# Altium schematic sheet 04-PCIe_JTAG.SchDoc(04-PCIe_JTAG)
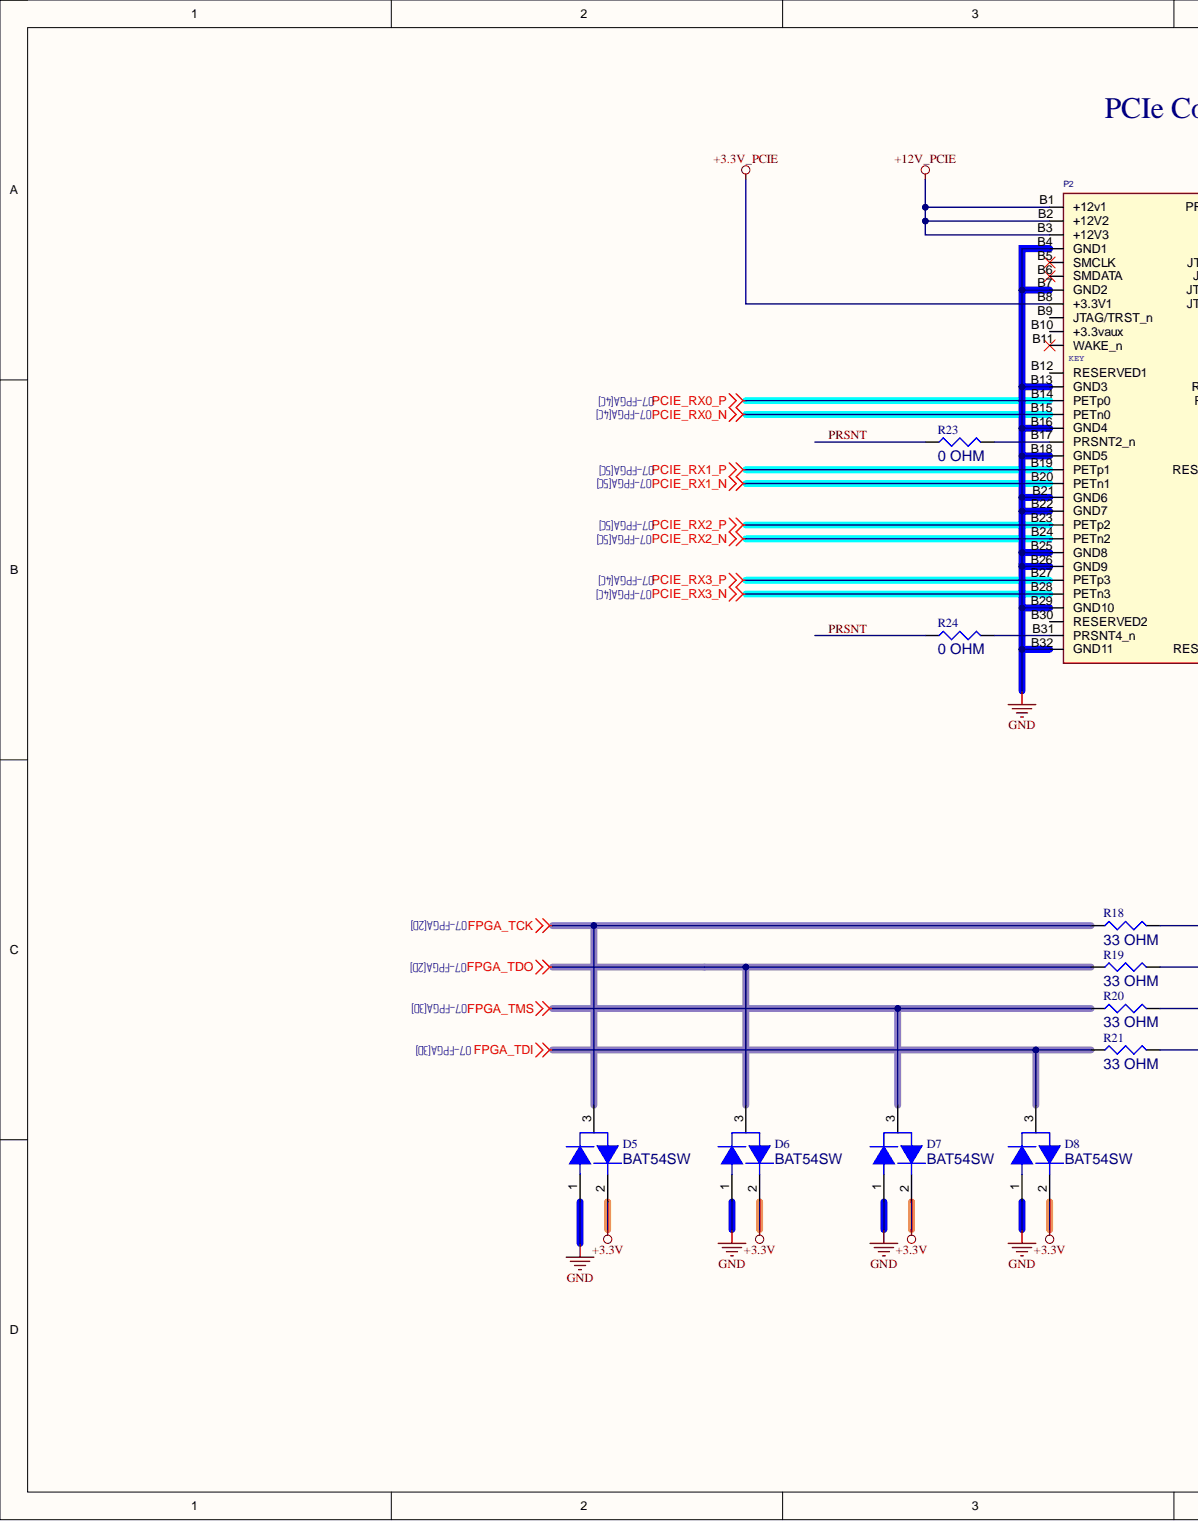
[diagram p0: 04-PCIe_JTAG.SchDoc, left]
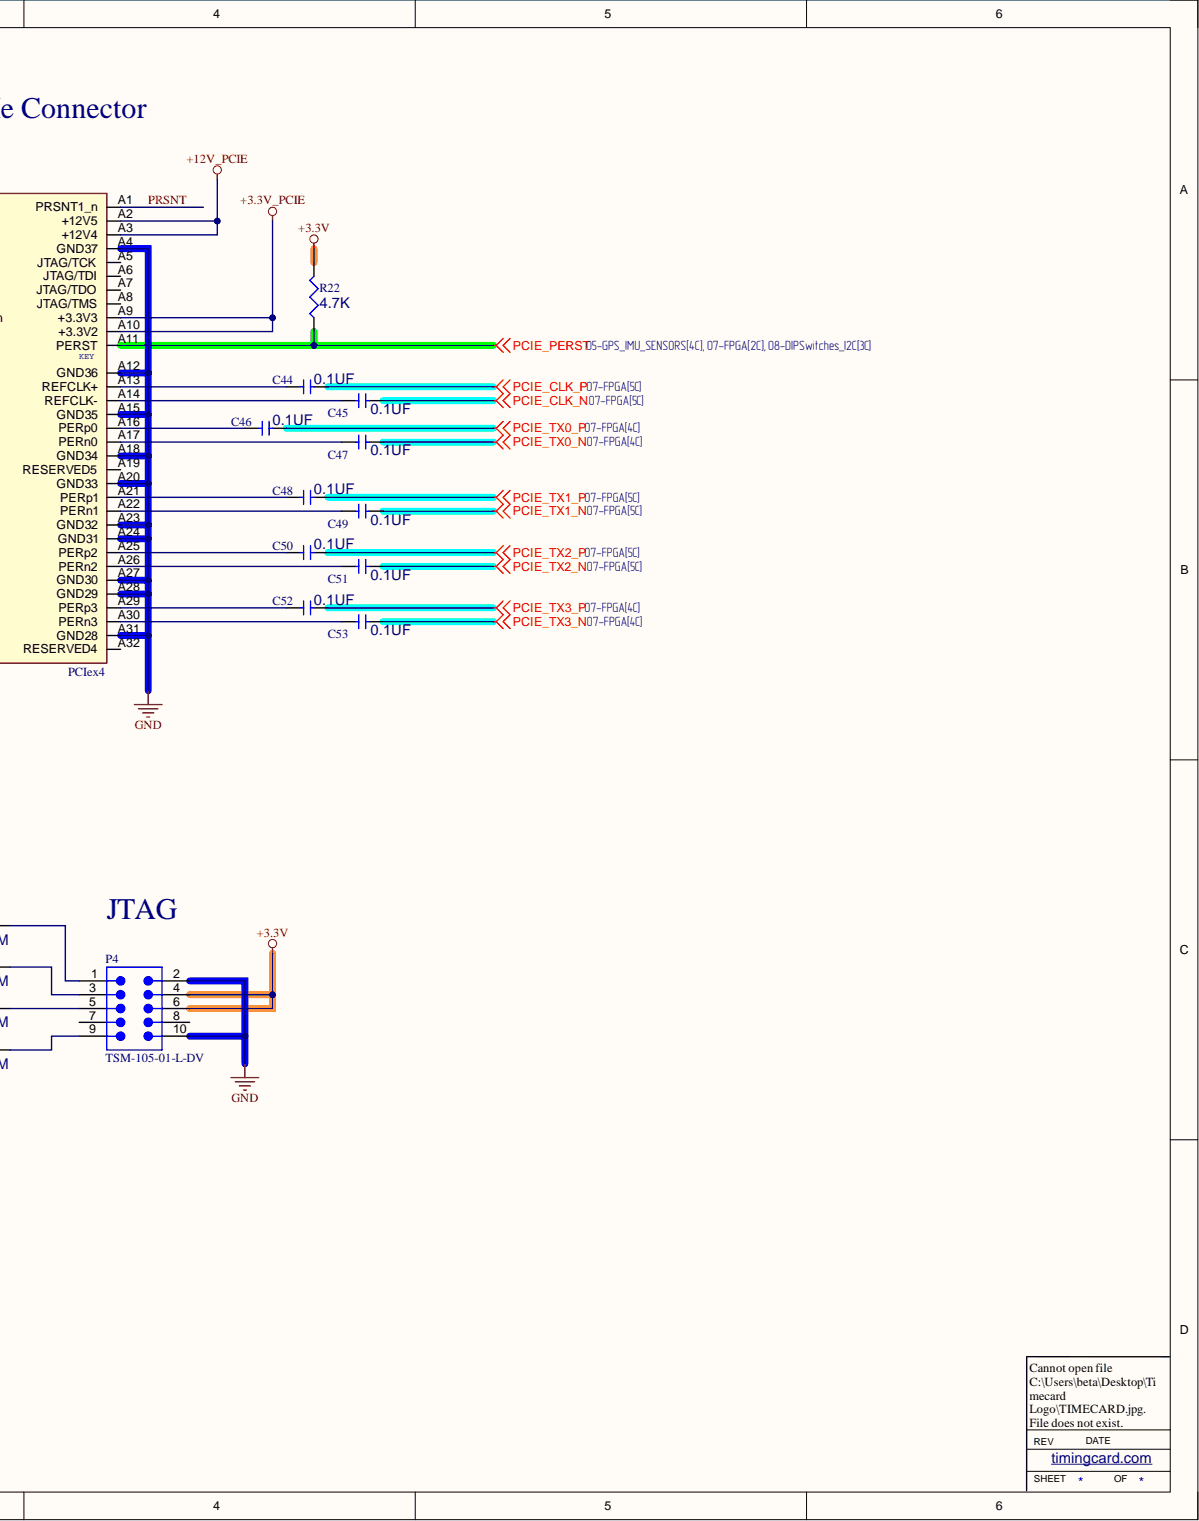
[diagram p1: 04-PCIe_JTAG.SchDoc, right]

## Parts
C44  value 0.1UF  [CAPC1005X06N]  (hidden comment: CAP_0402_0.1UF_50V)
C45  value 0.1UF  [CAPC1005X06N]  (hidden comment: CAP_0402_0.1UF_50V)
C46  value 0.1UF  [CAPC1005X06N]  (hidden comment: CAP_0402_0.1UF_50V)
C47  value 0.1UF  [CAPC1005X06N]  (hidden comment: CAP_0402_0.1UF_50V)
C48  value 0.1UF  [CAPC1005X06N]  (hidden comment: CAP_0402_0.1UF_50V)
C49  value 0.1UF  [CAPC1005X06N]  (hidden comment: CAP_0402_0.1UF_50V)
C50  value 0.1UF  [CAPC1005X06N]  (hidden comment: CAP_0402_0.1UF_50V)
C51  value 0.1UF  [CAPC1005X06N]  (hidden comment: CAP_0402_0.1UF_50V)
C52  value 0.1UF  [CAPC1005X06N]  (hidden comment: CAP_0402_0.1UF_50V)
C53  value 0.1UF  [CAPC1005X06N]  (hidden comment: CAP_0402_0.1UF_50V)
D5  BAT54SW  [SOT65P210X110-3N]
D6  BAT54SW  [SOT65P210X110-3N]
D7  BAT54SW  [SOT65P210X110-3N]
D8  BAT54SW  [SOT65P210X110-3N]
P2  PCIex4  [PCIE_4LANE_EDGE]  (no BOM)
P4  TSM-105-01-L-DV  [SMTC-TSM-105-01-X-DV]
R18  value 33 OHM  [RESC2012X50N]  (hidden comment: CRCW080533R0FKEA)
R19  value 33 OHM  [RESC2012X50N]  (hidden comment: CRCW080533R0FKEA)
R20  value 33 OHM  [RESC2012X50N]  (hidden comment: CRCW080533R0FKEA)
R21  value 33 OHM  [RESC2012X50N]  (hidden comment: CRCW080533R0FKEA)
R22  value 4.7K  [RESC1608X50N]  (hidden comment: ERJ-3EKF4701V)
R23  value 0 OHM  [RESC1005X04N]  (hidden comment: ERJ-2GE0R00X)
R24  value 0 OHM  [RESC1005X04N]  (hidden comment: ERJ-2GE0R00X)

## Nets
+3.3V: D5.2 D6.2 D7.2 D8.2 P4.4 P4.6 R22.2
+3.3V_PCIE: P2.A9(+3.3V3) P2.A10(+3.3V2) P2.B8(+3.3V1)
+12V_PCIE: P2.A2(+12V5) P2.A3(+12V4) P2.B1(+12v1) P2.B2(+12V2) P2.B3(+12V3)
FPGA_TCK: D5.3 R18.1
FPGA_TDI: D8.3 R21.1
FPGA_TDO: D6.3 R19.1
FPGA_TMS: D7.3 R20.1
GND: D5.1 D6.1 D7.1 D8.1 P2.A4(GND37) P2.A12(GND36) P2.A15(GND35) P2.A18(GND34) P2.A20(GND33) P2.A23(GND32) P2.A24(GND31) P2.A27(GND30) P2.A28(GND29) P2.A31(GND28) P2.B4(GND1) P2.B7(GND2) P2.B13(GND3) P2.B16(GND4) P2.B18(GND5) P2.B21(GND6) P2.B22(GND7) P2.B25(GND8) P2.B26(GND9) P2.B29(GND10) P2.B32(GND11) P4.2 P4.10
PCIE_CLK_N: C45.2
PCIE_CLK_P: C44.2
PCIE_PERST: P2.A11(PERST) R22.1
PCIE_RX0_N: P2.B15(PETn0)
PCIE_RX0_P: P2.B14(PETp0)
PCIE_RX1_N: P2.B20(PETn1)
PCIE_RX1_P: P2.B19(PETp1)
PCIE_RX2_N: P2.B24(PETn2)
PCIE_RX2_P: P2.B23(PETp2)
PCIE_RX3_N: P2.B28(PETn3)
PCIE_RX3_P: P2.B27(PETp3)
PCIE_TX0_N: C47.2
PCIE_TX0_P: C46.2
PCIE_TX1_N: C49.2
PCIE_TX1_P: C48.2
PCIE_TX2_N: C51.2
PCIE_TX2_P: C50.2
PCIE_TX3_N: C53.2
PCIE_TX3_P: C52.2
PRSNT: P2.A1(PRSNT1_n) R23.1 R24.1
NetC44_1: C44.1 P2.A13(REFCLK+)
NetC45_1: C45.1 P2.A14(REFCLK-)
NetC46_1: C46.1 P2.A16(PERp0)
NetC47_1: C47.1 P2.A17(PERn0)
NetC48_1: C48.1 P2.A21(PERp1)
NetC49_1: C49.1 P2.A22(PERn1)
NetC50_1: C50.1 P2.A25(PERp2)
NetC51_1: C51.1 P2.A26(PERn2)
NetC52_1: C52.1 P2.A29(PERp3)
NetC53_1: C53.1 P2.A30(PERn3)
NetP2_B17: P2.B17(PRSNT2_n) R23.2
NetP2_B31: P2.B31(PRSNT4_n) R24.2
NetP4_1: P4.1 R18.2
NetP4_3: P4.3 R19.2
NetP4_5: P4.5 R20.2
NetP4_9: P4.9 R21.2

Not connected: P2 A5(JTAG/TCK) A6(JTAG/TDI) A7(JTAG/TDO) A8(JTAG/TMS) A19(RESERVED5) A32(RESERVED4) B5(SMCLK) B6(SMDATA) B9(JTAG/TRST_n) B10(+3.3vaux) B11(WAKE_n) B12(RESERVED1) B30(RESERVED2); P4 7 8

## Library data of the parts
C44-C53 = CAP_0402_0.1UF_50V: CAP, CER, 0.1UF, 50V, 10%, X7R, AEC-Q200, 0402; MFG PART NUM: CGA2B3X7R1H104K050BB; VALENTIUM PART NUM: <VALENTIUM>; MAXTEMP: 125C; MFG NAME: TDK; MINTEMP: -55C; CATEGORY: CAP, CER; Component Kind: Standard; DESC: CAP, CER, 0.1UF, 50V, 10%, X7R, AEC-Q200, 0402; Library Name: Capacitors.IntLib; MODIFY DATE: 10/23/2013; OTHER: AEC-Q200; P1: 10%; P2: 50V; P3: X7R; Size: 0402; Supplier 1: Digi-Key; Supplier Part Number 1: 445-6899-1-ND
D5-D8 = BAT54SW: DIO, SCHOTTKY, BAT54S, DUAL SERIES, 30V, 200MA, SC-70; MFG PART NUM: BAT54SWQ-7-F; VALENTIUM PART NUM: <VALENTIUM>; MAXTEMP: 150C; MFG NAME: DIODES INC; MINTEMP: -55C; P3: 200mA,200mW; CATEGORY: DIO, SCHOTTKY; Component Kind: Standard; DESC: DIO, SCHOTTKY, BAT54S, DUAL SERIES, 30V, 200MA, SC-70; Library Name: Passives.IntLib; MODIFY DATE: 9/4/2013; OTHER: SERIES; P1: SCHOTTKY; P2: 30V; SIZE: SC-70; Supplier 1: Digi-Key; Supplier Part Number 1: BAT54SWQ-7-FDICT-ND; VALUE: BAT54SW
P2 = PCIex4: PCIE x4 Edge Connector,OrCAD Symbol,NC; Manufacturer: NA; Part Number: 451; Library Name: Connectors.IntLib; NOTE: NC; Part Type: SMT; Vendor P/N: NA
P4 = CMP-1024-00233-1: 0.025" SQ Post Header, Surface Mount, Vertical, -55 to 125 degC, 2.54 mm Pitch, 10-Pin, Male, RoHS; Manufacturer: Samtec; Pitch: 2.54 mm; Component Kind: Standard; ComponentLink1Description: Manufacturer URL; ComponentLink1URL: http://www.samtec.com; ComponentLink2Description: Datasheet; ComponentLink2URL: http://www.samtec.com/documents/webfiles/pdf/TSM.PDF; ComponentLink3Description: Package Specification; ComponentLink3URL: http://www.samtec.com/documents/webfiles/cpdf/TSM-1XX-XX-XXX-XX-X-XXX-X-MKT.pdf; DatasheetVersion: F-214; Library Name: Altium Content Vault; Mounting Technology: Surface Mount; Orientation: Vertical; PackageDescription: Double Row Gullwing ASM; PackageReference: TSM-105-01-X-DV; PackageVersion: DJ, 4/1991; PartNumber: TSM-105-01-L-DV; RoHS: True; Temperature Range: -55 to 125 degC
R18 = RES_0805_33: RES, 33 OHM, 1%, 1/8W, TF, 0805; VELENTIUM PART NUM: <VELENTIUM>; MAXTEMP: 125C; MFG NAME: VISHAY/DALE; MINTEMP: -55C; OTHER: 100PPM; VALUE: 33 OHM; CATEGORY: RES; Component Kind: Standard; DESC: RES, 33 OHM, 1%, 1/8W, TF, 0805; Library Name: Resistors.IntLib; MFG PART NUM: CRCW080533R0FKEA; ModifyDate: 7/26/2013; P1: 1%; P2: 1/8W; P3: TF; SIZE: 0805; Supplier 1: Digi-Key; Supplier Part Number 1: 541-33.0CCT-ND
R19-R21 = RES_0805_33: RES, 33 OHM, 1%, 1/8W, TF, 0805; VELENTIUM PART NUM: <VELENTIUM>; MAXTEMP: 125C; MFG NAME: VISHAY/DALE; MINTEMP: -55C; OTHER: 100PPM; CATEGORY: RES; Component Kind: Standard; DESC: RES, 33 OHM, 1%, 1/8W, TF, 0805; Library Name: Resistors.IntLib; MFG PART NUM: CRCW080533R0FKEA; ModifyDate: 7/26/2013; P1: 1%; P2: 1/8W; P3: TF; SIZE: 0805; Supplier 1: Digi-Key; Supplier Part Number 1: 541-33.0CCT-ND
R22 = RES_0603_4.7K: RES, 4.7K, 1%, 1/10W, TF, 0603; VELENTIUM PART NUM: <VELENTIUM>; MAXTEMP: 125C; MFG NAME: PANASONIC; MINTEMP: -55C; OTHER: 100PPM; SUB: GENERIC; CATEGORY: RES; Component Kind: Standard; Date: 7/26/2013; DESC: RES, 4.7K, 1%, 1/10W, TF, 0603; Library Name: Resistors.IntLib; MFG PART NUM: ERJ-3EKF4701V; ModifyDate: 7/25/2013; P1: 1%; P2: 1/10W; P3: TF; SIZE: 0603; Supplier 1: Digi-Key; Supplier Part Number 1: P4.70KHCT-ND
R23 R24 = RES_0402_0: RES, 0. OHM, 1%, 1/16W, TF, AEC-Q200, 0402; VELENTIUM PART NUM: <VELENTIUM>; DESC: RES, 0 OHM, 1%, 1/16W, TF, AEC-Q200, 0402; MAXTEMP: 155C; MFG NAME: PANASONIC; MINTEMP: -55C; OTHER: 100PPM, AEC-Q200; SUB: GENERIC; CATEGORY: RES; Component Kind: Standard; Date: 7/26/2013; Library Name: Resistors.IntLib; MFG PART NUM: ERJ-2GE0R00X; ModifyDate: 7/25/2013; P1: 1%; P2: 1/16W; P3: TF; SIZE: 0402; Supplier 1: Digi-Key; Supplier Part Number 1: P0.0JCT-ND
